# Altium schematic sheet Power Management.SchDoc("Power Management")
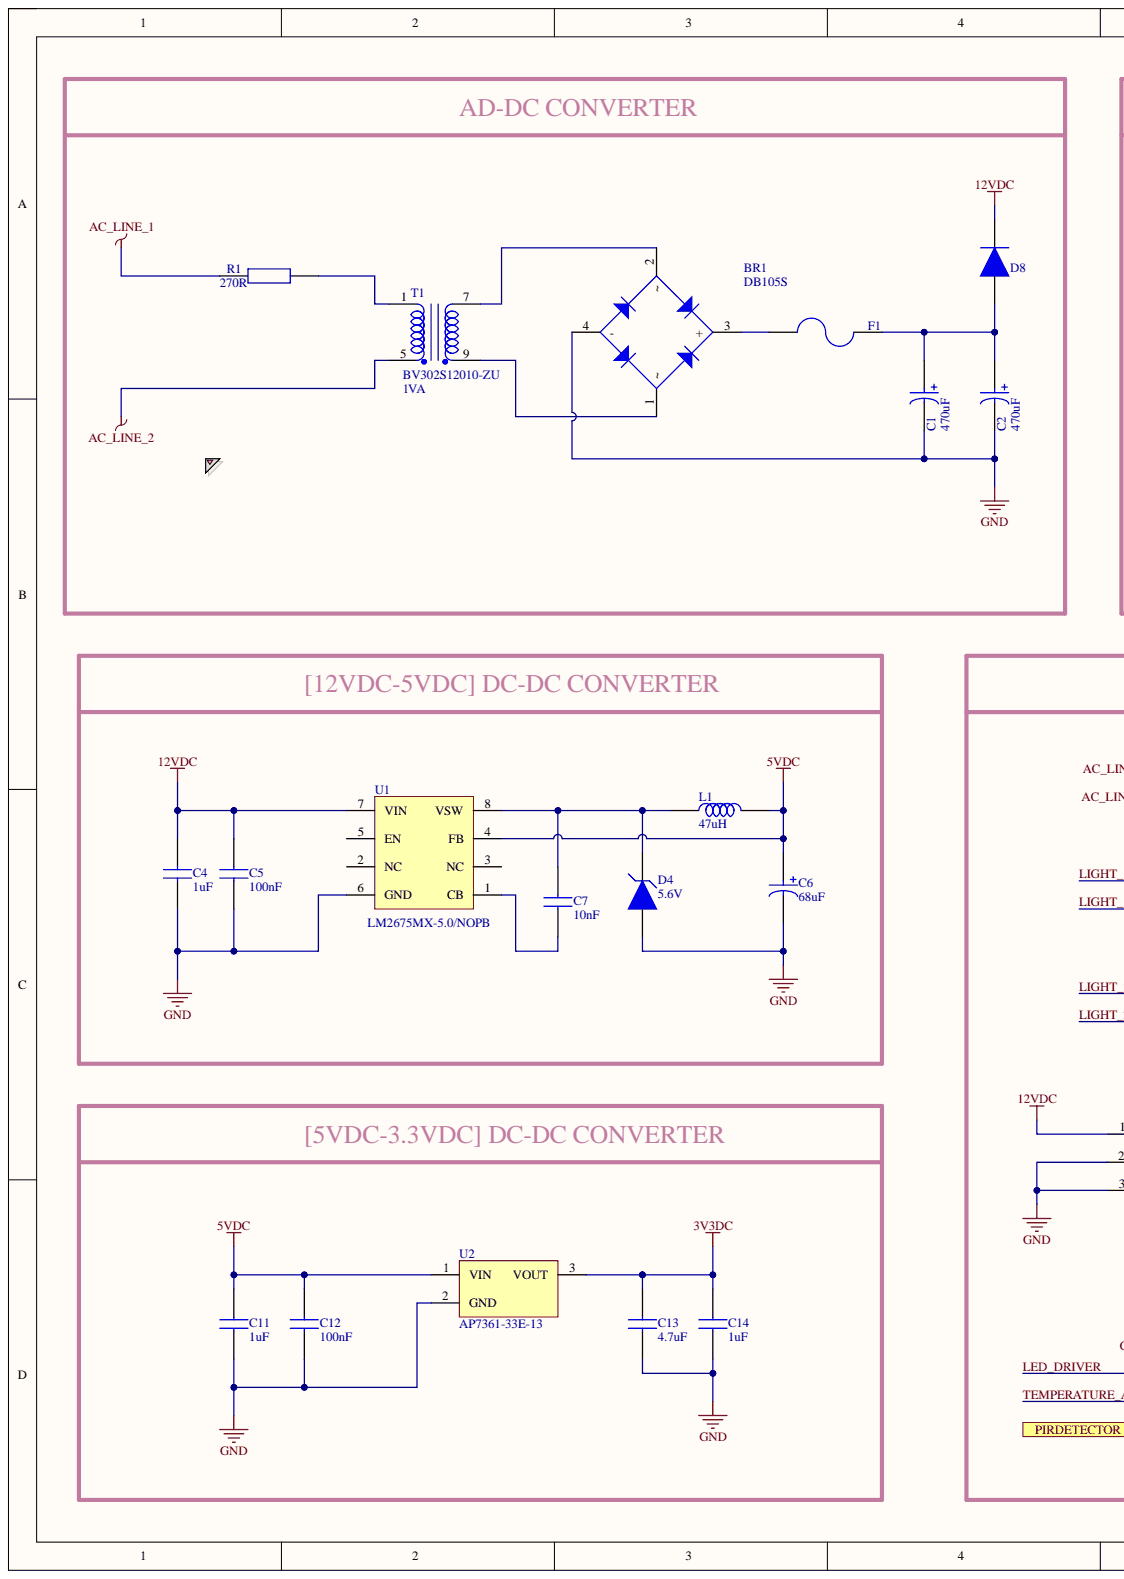
[diagram p0: Power Management.SchDoc, left]
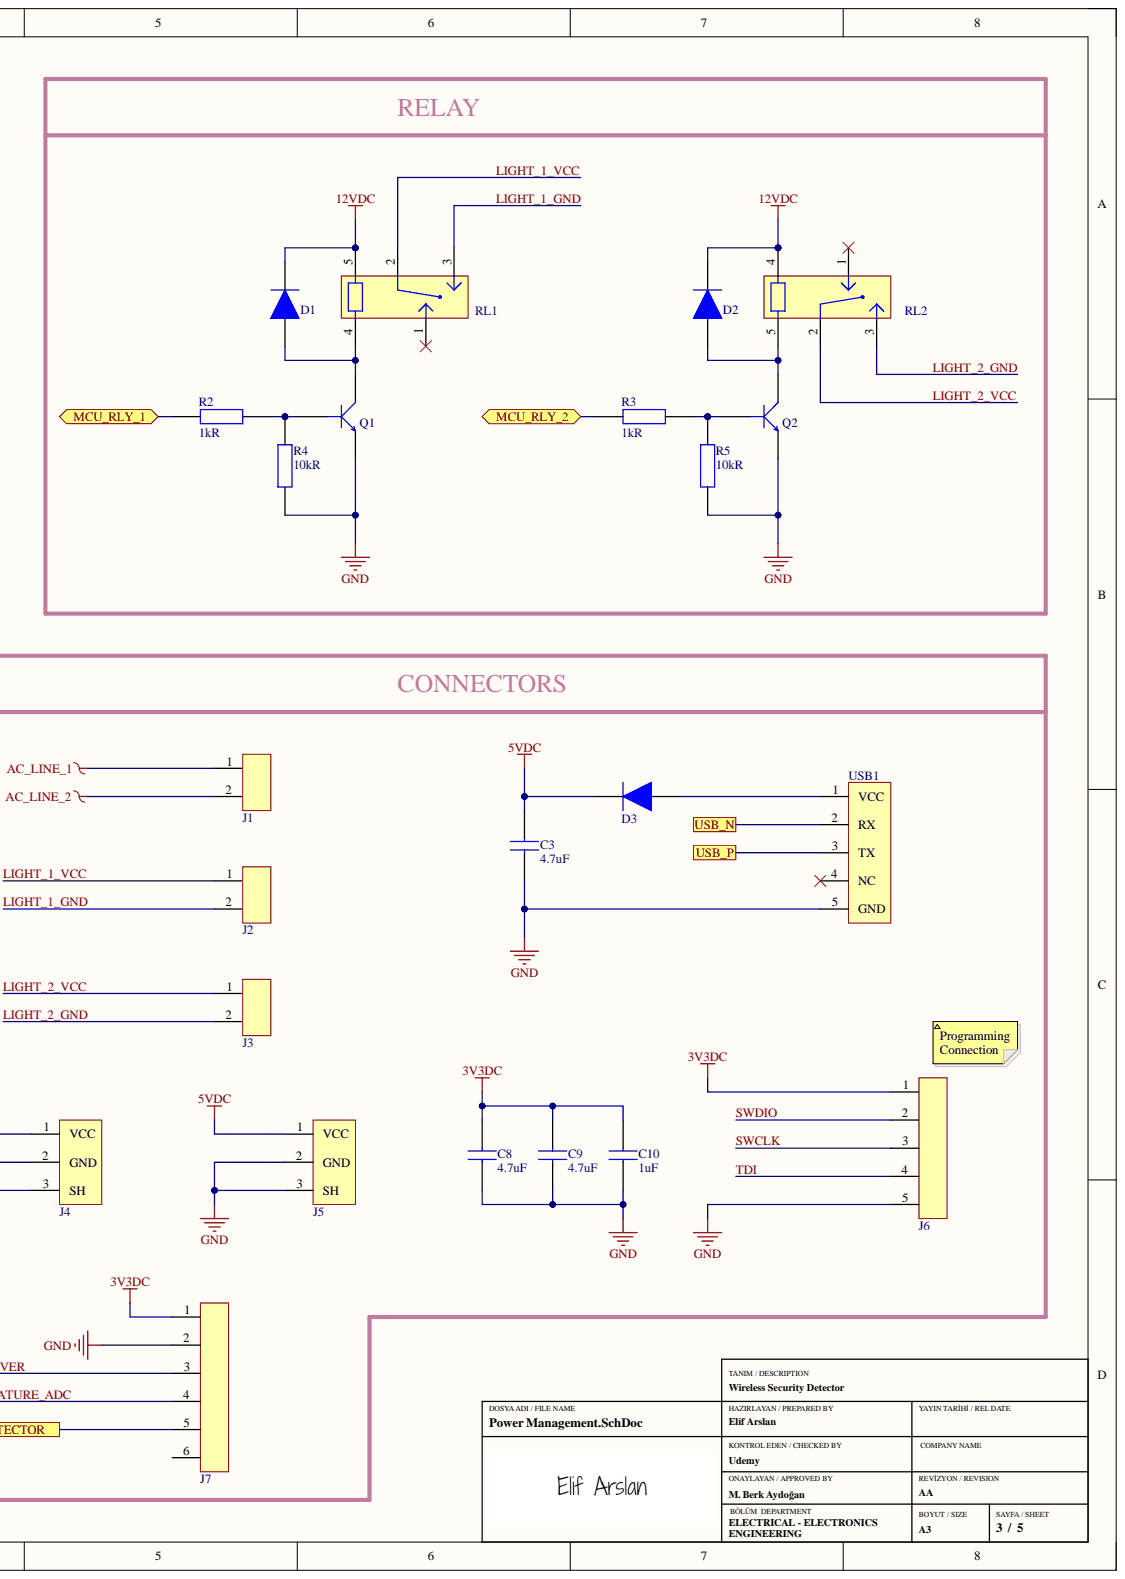
[diagram p1: Power Management.SchDoc, right]

## Parts
BR1  DB105S  [DB105S]
C1  Capacitance: 470uF  [CAP_RADIAL_D10_P5]  (hidden comment: ESH477M050AL3AA)
C2  Capacitance: 470uF  [CAP_RADIAL_D10_P5]  (hidden comment: ESH477M050AL3AA)
C3  Capacitance: 4.7uF  [CAP_0805]  (hidden comment: CL21A475KAQNNNE)
C4  Capacitance: 1uF  [CAP_0805]  (hidden comment: CL21B105KBFNNNE)
C5  Capacitance: 100nF  [CAP_0805]  (hidden comment: CL21B104KBCNNNC)
C6  Capacitance: 68uF  [CAP_EEVseries]  (hidden comment: EEV686M035A9HAA)
C7  Capacitance: 10nF  [CAP_0603]  (hidden comment: 0603B103K160CT)
C8  Capacitance: 4.7uF  [CAP_0805]  (hidden comment: CL21A475KAQNNNE)
C9  Capacitance: 4.7uF  [CAP_0805]  (hidden comment: CL21A475KAQNNNE)
C10  Capacitance: 1uF  [CAP_0805]  (hidden comment: CL21B105KBFNNNE)
C11  Capacitance: 1uF  [CAP_0805]  (hidden comment: CL21B105KBFNNNE)
C12  Capacitance: 100nF  [CAP_0603]  (hidden comment: C0603C104K4RACTU)
C13  Capacitance: 4.7uF  [CAP_0805]  (hidden comment: CL21A475KAQNNNE)
C14  Capacitance: 1uF  [CAP_0805]  (hidden comment: CL21B105KBFNNNE)
D1  1N4148WS  [SOD323F]  (value not drawn)
D2  1N4148WS  [SOD323F]  (value not drawn)
D3  1N4148WS  [SOD323F]  (value not drawn)
D4  Voltage Rating (DC): 5.6V  [SMB]  (hidden comment: 1SMB5919BT3G)
D8  1N4148WS  [SOD323F]  (value not drawn)
F1  FUSE 3413.0216.22  [3413.0216.22]  (value not drawn)
J1  TBP01R1-508-02BE  [TBP01R1-508]  (value not drawn)
J2  TBP01R1-508-02BE  [TBP01R1-508]  (value not drawn)
J3  TBP01R1-508-02BE  [TBP01R1-508]  (value not drawn)
J4  PJ-037AH-SMT-TR  [JACK]  (value not drawn)
J5  PJ-037AH-SMT-TR  [JACK]  (value not drawn)
J6  SMH-105-02-L-S  [PINHEADER_1x5_RA]  (value not drawn)
J7  64800611622  [64800611622]  (value not drawn)
L1  Inductance: 47uH  [IND_1212]  (hidden comment: 78438335470)
Q1  MMBT3904-7-F  [SOT-23F]  (value not drawn)
Q2  MMBT3904-7-F  [SOT-23F]  (value not drawn)
R1  Resistance: 270R  [RES_AXIAL_W]  (hidden comment: 5WD27)
R2  Resistance: 1kR  [RES_0603]  (hidden comment: RC0603FR-071KL)
R3  Resistance: 1kR  [RES_0603]  (hidden comment: RC0603FR-071KL)
R4  Resistance: 10kR  [RES_0603]  (hidden comment: RC0603FR-0710KL)
R5  Resistance: 10kR  [RES_0603]  (hidden comment: RC0603FR-0710KL)
RL1  ALQ112  [ALQ112]  (value not drawn)
RL2  ALQ112  [ALQ112]  (value not drawn)
T1  BV302S12010-ZU  Rated Power: 1VA  [BV302S]
U1  LM2675MX-5.0/NOPB  [SOIC8]
U2  AP7361-33E-13  [SOT-223]
USB1  10118192-0001LF  [MICRO_B_USB]  (value not drawn)

## Ports
MCU_RLY_1, MCU_RLY_2, PIRDETECTOR, USB_N, USB_P

## Nets
3V3DC: C8.2 C9.2 C10.2 C13.2 C14.2 J6.1 J7.1 U2.3
5VDC: C3.2 C6.1 C11.2 C12.2 D3.1 J5.1 L1.2 U1.4(FB) U2.1
12VDC: C4.2 C5.2 D1.1 D2.1 D8.1 J4.1 RL1.5 RL2.4 U1.7(VIN)
AC_LINE_1: J1.1 R1.1
AC_LINE_2: J1.2 T1.5
GND: BR1.4(-) C1.2 C2.2 C3.1 C4.1 C5.1 C6.2 C8.1 C9.1 C10.1 C11.1 C12.1 C13.1 C14.1 D4.A J4.2 J4.3 J5.2 J5.3 J6.5 J7.2 Q1.2 Q2.2 R4.1 R5.1 U1.6(GND) U2.2 USB1.5(GND)
LED_DRIVER: J7.3
LIGHT_1_GND: J2.2 RL1.3
LIGHT_1_VCC: J2.1 RL1.2
LIGHT_2_GND: J3.2 RL2.3
LIGHT_2_VCC: J3.1 RL2.2
MCU_RLY_1: R2.1 ; port MCU_RLY_1
MCU_RLY_2: R3.1 ; port MCU_RLY_2
PIRDETECTOR: J7.5 ; port PIRDETECTOR
SWCLK: J6.3
SWDIO: J6.2
TDI: J6.4
TEMPERATURE_ADC: J7.4
USB_N: USB1.2(RX) ; port USB_N
USB_P: USB1.3(TX) ; port USB_P
NetBR1_1: BR1.1(~) T1.9
NetBR1_2: BR1.2(~) T1.7
NetBR1_3: BR1.3(+) F1.1
NetC1_1: C1.1 C2.1 D8.2 F1.2
NetC7_1: C7.1 U1.1(CB)
NetC7_2: C7.2 D4.C L1.1 U1.8(VSW)
NetD1_2: D1.2 Q1.3 RL1.4
NetD2_2: D2.2 Q2.3 RL2.5
NetD3_2: D3.2 USB1.1(VCC)
NetQ1_1: Q1.1 R2.2 R4.2
NetQ2_1: Q2.1 R3.2 R5.2
NetR1_2: R1.2 T1.1

Not connected: J7 6; RL1 1; RL2 1; U1 2(NC) 3(NC) 5(EN); USB1 4(NC)

## Library data of the parts
BR1 = DB105S: Bridge Rect 1PHASE 600V 1A Db-s; Manufacturer: Sangdest Microelectronics; Category: Uncategorized; Component Kind: Standard; Library Name: UDEMY_Library.IntLib; Manufacturer Part Number: DB105S; Max Current: 1A; Operating Voltage: 600V; Pricing 1: 1=0,43000, 10=0,32600, 100=0,20330, 500=0,13910 (USD); RoHS: No; Stock 1: 30819; Supplier 1: Digi-Key; Supplier Part Number 1: 1655-DB105SCT-ND
C1 C2 = CAP ALU ELEC 470uF 50V: CAP ALUM 470UF 20% 50V RADIAL; Manufacturer: KEMET; Dielectric Material: Aluminium; Dissipation Factor: 12%; Lead Diameter: 0.6mm; Lead Length: 20mm; Leakage Current: 235uA; Life (Hours): 2000Hour; Polarity: Polar; Ripple Current: 730mA; Case/Package: Radial; Category: Aluminum Electrolytic Capacitors; Component Kind: Standard; ComponentLink1Description: https://datasheet.ciiva.com/18446/70233271-18446534.pdf?src-supplier=Allied+Electronics; ComponentLink1URL: https://datasheet.ciiva.com/18446/70233271-18446534.pdf?src-supplier=Allied+Electronics; ComponentLink2Description: https://datasheet.ciiva.com/22627/1794402-22627149.pdf?src-supplier=Farnell; ComponentLink2URL: https://datasheet.ciiva.com/22627/1794402-22627149.pdf?src-supplier=Farnell; Diameter: 13mm; Height: 20mm; Height - Seated (Max): 0.866inch; Lead Pitch: 5mm; Length: 20mm; Library Name: UDEMY_Library.IntLib; Manufacturer Part Number: ESH477M050AL3AA; Max Operating Temperature: 105°C; Min Operating Temperature: -40°C; Mount: Through Hole,PCB; Package Quantity: 2000; Packaging: Bulk; Pricing 1: 1=0,85000, 10=0,62700, 50=0,50140, 100=0,42970, 500=0,34020, 1000=0,28649, 2500=0,26858, 5000=0,25068 (USD); Radiation Hardening: No; REACH SVHC: No SVHC; RoHS: Yes; Stock 1: 4055; Supplier 1: Digi-Key; Supplier Part Number 1: 399-6594-ND; Termination: Radial; Tolerance: 20%; Voltage: 50V; Voltage Rating: 50V; Voltage Rating (DC): 50V
C3 C8 C9 C13 = CAP CER  4.7uF 0805: CAP CER 4.7UF 25V X5R %10 0805; Manufacturer: Samsung; Tolerance: 10%; Case Code (Metric): 2012; Case Code (Imperial): 0805; Case/Package: 0805; Category: Ceramic Capacitors; Component Kind: Standard; ComponentLink1Description: https://datasheet.ciiva.com/25798/cl21a475kaqnnne-25798882.pdf?src-supplier=Digi-Key; ComponentLink1URL: https://datasheet.ciiva.com/25798/cl21a475kaqnnne-25798882.pdf?src-supplier=Digi-Key; Construction: Flat; Depth: 1.25mm; Dielectric: X5R; Height: 1.25mm; Lead Free: Lead Free; Length: 2mm; Library Name: UDEMY_Library.IntLib; Manufacturer Part Number: CL21A475KAQNNNE; Max Operating Temperature: 85°C; Min Operating Temperature: -55°C; Mount: Surface Mount; Package Quantity: 1; Packaging: Tape and Reel; Pricing 1: 1=0,10000, 10=0,07300, 50=0,04140, 100=0,03440, 500=0,02408, 1000=0,01994 (USD); Radiation Hardening: No; RoHS: Yes; RoHS Compliant: Yes; Series: CL; Stock 1: 5034219; Supplier 1: Digi-Key; Supplier Part Number 1: 1276-1244-1-ND; Thickness: 0.055inch; Voltage Rating: 25V; Voltage Rating (DC): 25V; Width: 0.049inch
C4 C10 C11 C14 = CAP CER 1uF 0805: CAP CER 1UF %10 50V 0805; Manufacturer: Samsung; Dielectric: X7R; Tolerance: 10%; Case Code (Metric): 2012; Case Code (Imperial): 0805; Case/Package: 0805; Category: Ceramic Capacitors; Component Kind: Standard; ComponentLink1Description: https://datasheet.ciiva.com/25800/cl21b105kbfnnne-25800291.pdf?src-supplier=Digi-Key; ComponentLink1URL: https://datasheet.ciiva.com/25800/cl21b105kbfnnne-25800291.pdf?src-supplier=Digi-Key; ComponentLink2Description: https://datasheet.ciiva.com/16449/cl21b105kbfnnne-16449003.pdf?src-supplier=Future+Electronics; ComponentLink2URL: https://datasheet.ciiva.com/16449/cl21b105kbfnnne-16449003.pdf?src-supplier=Future+Electronics; ComponentLink3Description: https://datasheet.ciiva.com/26838/getdatasheetpartid-354075-26838668.pdf?src-supplier=Verical; ComponentLink3URL: https://datasheet.ciiva.com/26838/getdatasheetpartid-354075-26838668.pdf?src-supplier=Verical; Construction: Flat; Depth: 1.25mm; Height: 1.25mm; Length: 2mm; Library Name: UDEMY_Library.IntLib; Manufacturer Part Number: CL21B105KBFNNNE; Max Operating Temperature: 125°C; Min Operating Temperature: -55°C; Mount: Surface Mount; Package Quantity: 1; Packaging: Tape and Reel; Pricing 1: 1=0,11000, 10=0,07900, 50=0,04500, 100=0,03730, 500=0,02616, 1000=0,02165 (USD); Radiation Hardening: No; RoHS: Yes; RoHS Compliant: Yes; Series: CL; Stock 1: 177693; Supplier 1: Digi-Key; Supplier Part Number 1: 1276-1029-1-ND; Thickness: 0.053inch; Voltage: 50V; Voltage Rating: 50V; Width: 0.049inch
C5 = CAP CER 100nF 0805: CAP CER 0.1UF  %10 50V X7R  0805; Manufacturer: Samsung; Material: Ceramic; Tolerance: 10%; Case Code (Metric): 2012; Case Code (Imperial): 0805; Case/Package: 0805; Category: Ceramic Capacitors; Component Kind: Standard; ComponentLink1Description: https://datasheet.ciiva.com/25710/cl21b104kbcnnnc-25710182.pdf?src-supplier=Digi-Key; ComponentLink1URL: https://datasheet.ciiva.com/25710/cl21b104kbcnnnc-25710182.pdf?src-supplier=Digi-Key; ComponentLink2Description: https://datasheet.ciiva.com/29199/454152-da-01-en-vs-kondensator-0805-29199814.pdf?src-supplier=Conrad; ComponentLink2URL: https://datasheet.ciiva.com/29199/454152-da-01-en-vs-kondensator-0805-29199814.pdf?src-supplier=Conrad; Construction: Flat; Contact Plating: Tin; Depth: 1.25mm; Dielectric: X7R; Height: 0.85mm; Lead Free: Lead Free; Length: 2mm; Library Name: UDEMY_Library.IntLib; Manufacturer Part Number: CL21B104KBCNNNC; Max Operating Temperature: 125°C; Min Operating Temperature: -55°C; Mount: Surface Mount; Packaging: Tape and Reel; Pricing 1: 1=0,10000, 10=0,04400, 50=0,02400, 100=0,01960, 500=0,01404, 1000=0,01103 (USD); Radiation Hardening: No; RoHS: Yes; RoHS Compliant: Yes; Series: CL; Stock 1: 4716074; Supplier 1: Digi-Key; Supplier Part Number 1: 1276-1003-1-ND; Thickness: 0.037inch; Voltage: 50V; Voltage Rating: 50V; Voltage Rating (DC): 50V; Width: 0.049inch
C6 = CAP ALU 68uF: CAP ALUM 68UF 20% 35V SMD; Manufacturer: KEMET; Life (Hours): 2000Hour; Ripple Current: 196mA; Impedance: 340mR; Case/Package: Radial; Category: Aluminum Electrolytic Capacitors; Component Kind: Standard; ComponentLink1Description: https://datasheet.ciiva.com/5365/kem-a4002-eev-535332-5365784.pdf?src-supplier=Mouser; ComponentLink1URL: https://datasheet.ciiva.com/5365/kem-a4002-eev-535332-5365784.pdf?src-supplier=Mouser; Height - Seated (Max): 0.315inch; Length: 7.7mm; Library Name: UDEMY_Library.IntLib; Manufacturer Part Number: EEV686M035A9HAA; Max Operating Temperature: 105°C; Min Operating Temperature: -40°C; Mount: Surface Mount; Package Quantity: 1; Packaging: Tape and Reel; Pricing 1: 1=0,67000, 10=0,47200, 50=0,35680, 100=0,31080, 500=0,23024 (USD); RoHS: Yes; RoHS Compliant: Yes; Series: EEV; Stock 1: 9381; Supplier 1: Digi-Key; Supplier Part Number 1: 399-6722-1-ND; Termination: SMD/SMT; Tolerance: 20%; Voltage Rating: 35V; Voltage Rating (DC): 35V
C7 = CAP CER 10nF 0603: CAP CER 10nF %10 0603; Manufacturer: Walsin Technologies; Dielectric: X7R; Tolerance: 10%; Voltage Rating: 16V; Case Code (Metric): 1608; Case Code (Imperial): 0603; Case/Package: 0603; Category: Ceramic Capacitors; Component Kind: Standard; ComponentLink1Description: http://www.farnell.com/datasheets/1958513.pdf?src-supplier=Farnell; ComponentLink1URL: http://www.farnell.com/datasheets/1958513.pdf?src-supplier=Farnell; ComponentLink2Description: http://www.farnell.com/datasheets/1958513.pdf?src-supplier=Element14; ComponentLink2URL: http://www.farnell.com/datasheets/1958513.pdf?src-supplier=Element14; ComponentLink3Description: http://www.passivecomponent.com/wp-content/uploads/2018/10/MLCC.pdf?src-supplier=Digi-Key; ComponentLink3URL: http://www.passivecomponent.com/wp-content/uploads/2018/10/MLCC.pdf?src-supplier=Digi-Key; Depth: 0.8mm; Length: 1.6mm; Library Name: UDEMY_Library.IntLib; Manufacturer Part Number: 0603B103K160CT; Max Operating Temperature: 125°C; Min Operating Temperature: -55°C; Packaging: Tape and Reel; Pricing 1: 1=0,10000, 10=0,03300, 50=0,01820, 100=0,01480, 500=0,01060, 1000=0,00833 (USD); REACH SVHC: Unknown; RoHS: Yes; RoHS Compliant: Yes; Stock 1: 155945; Supplier 1: Digi-Key; Supplier Part Number 1: 1292-1384-1-ND; Voltage Rating (DC): 16V
C12 = CAP CER 100nF 0603: CAP CER 100nF %10 0603 SMD; Manufacturer: KEMET; Dielectric: X7R; Dielectric Material: Ceramic; Dissipation Factor: 3.5%; Tolerance: 10%; Voltage: 16V; Case Code (Metric): 1608; Case Code (Imperial): 0603; Case/Package: 0603; Category: Ceramic Capacitors; Component Kind: Standard; ComponentLink1Description: https://datasheet.ciiva.com/22749/kem-c1002-x7r-smd-22749657.pdf?src-supplier=Element14; ComponentLink1URL: https://datasheet.ciiva.com/22749/kem-c1002-x7r-smd-22749657.pdf?src-supplier=Element14; ComponentLink2Description: https://datasheet.ciiva.com/14589/70095383-14589014.pdf?src-supplier=Allied+Electronics; ComponentLink2URL: https://datasheet.ciiva.com/14589/70095383-14589014.pdf?src-supplier=Allied+Electronics; ComponentLink3Description: https://datasheet.ciiva.com/5413/kem-c1002-x7r-smd-5413791.pdf?src-supplier=Mouser; ComponentLink3URL: https://datasheet.ciiva.com/5413/kem-c1002-x7r-smd-5413791.pdf?src-supplier=Mouser; ComponentLink4Description: https://datasheet.ciiva.com/18192/getdatasheetpartid-330584-18192695.pdf?src-supplier=Verical; ComponentLink4URL: https://datasheet.ciiva.com/18192/getdatasheetpartid-330584-18192695.pdf?src-supplier=Verical; Construction: Flat; Contact Plating: Tin,Matte; Depth: 0.8mm; Height: 0.8mm; Lead Pitch: 0.7mm; Length: 1.6mm; Library Name: UDEMY_Library.IntLib; Manufacturer Part Number: C0603C104K4RACTU; Max Operating Temperature: 125°C; Min Operating Temperature: -55°C; Mount: PCB,Surface Mount; Number of Pins: 2; Package Quantity: 4000; Packaging: Tape and Reel; Pricing 1: 1=0,10000, 10=0,07200, 50=0,03940, 100=0,03220, 500=0,02298, 1000=0,01805 (USD); Radiation Hardening: No; REACH SVHC: No SVHC; RoHS: Yes; Series: C0603C; Stock 1: 3101112; Supplier 1: Digi-Key; Supplier Part Number 1: 399-C0603C104K4RAC7867CT-ND; Termination: SMD/SMT; Thickness: 0.034inch; Voltage Rating: 16V; Voltage Rating (DC): 16V; Weight: 7.1E-05oz; Width: 0.8mm
D1-D3 D8 = 1N4148WS: VR=75V IF=150mA Trr=4ns P=200mW; Manufacturer: ON Semiconductor / Fairchild; Average Rectified Current: 150mA; Element Configuration: Single; Forward Voltage: 1V; Max Forward Surge Current (Ifsm): 2A; Max Repetitive Reverse Voltage (Vrrm): 75V; Max Reverse Current: 5uA; Power Dissipation: 200mW; Recovery Time: 4ns; Category: Rectifier Diodes; Component Kind: Standard; ComponentLink1Description: https://datasheet.ciiva.com/30479/0900766b810e1cd3-30479206.pdf?src-supplier=RSComponents; ComponentLink1URL: https://datasheet.ciiva.com/30479/0900766b810e1cd3-30479206.pdf?src-supplier=RSComponents; ComponentLink2Description: https://datasheet.ciiva.com/15208/getdatasheetpartid-127819-15208358.pdf?src-supplier=Verical; ComponentLink2URL: https://datasheet.ciiva.com/15208/getdatasheetpartid-127819-15208358.pdf?src-supplier=Verical; ComponentLink3Description: https://datasheet.ciiva.com/8300/1n914bws-8300360.pdf?src-supplier=Avnet; ComponentLink3URL: https://datasheet.ciiva.com/8300/1n914bws-8300360.pdf?src-supplier=Avnet; ComponentLink4Description: https://datasheet.ciiva.com/910/1n4148ws-910381.pdf?src-supplier=Digi-Key; ComponentLink4URL: https://datasheet.ciiva.com/910/1n4148ws-910381.pdf?src-supplier=Digi-Key; ComponentLink5Description: https://datasheet.ciiva.com/910/1n4148ws-910382.pdf?src-supplier=Digi-Key; ComponentLink5URL: https://datasheet.ciiva.com/910/1n4148ws-910382.pdf?src-supplier=Digi-Key; ComponentLink6Description: https://datasheet.ciiva.com/910/1n4148ws-910380.pdf?src-supplier=Digi-Key; ComponentLink6URL: https://datasheet.ciiva.com/910/1n4148ws-910380.pdf?src-supplier=Digi-Key; Contact Plating: Tin,Matte; Forward Current: 150mA; Height: 1mm; Lead Free: Lead Free; Length: 1.8mm; Library Name: UDEMY_Library.IntLib; Manufacturer Part Number: 1N4148WS; Max Operating Temperature: 150°C; Max Reverse Voltage (DC): 75V; Min Operating Temperature: -55°C; Mount: Surface Mount; Number of Pins: 2; Package Quantity: 1; Packaging: Tape and Reel; Pricing 1: 1=0,21000, 10=0,19200, 100=0,10460, 500=0,06436, 1000=0,04388 (USD); Radiation Hardening: No; REACH SVHC: No SVHC; Reverse Recovery Time: 4ns; Reverse Voltage: 75V; RoHS: Yes; RoHS Compliant: Yes; Series: -; Stock 1: 1631860; Supplier 1: Digi-Key; Supplier Part Number 1: 1N4148WSFSCT-ND; Weight: 0.0276g; Width: 1.35mm
D4 = 1SMB5919BT3G: Zener Diode 5.6 V 3 W ±5% Surface Mount SMB; Manufacturer: ON Semiconductor; Breakdown Voltage: 5.88V; Element Configuration: Single; ESD Protection: Yes; Forward Voltage: 1.5V; Material: Plastic; Max Power Dissipation: 3W; Max Reverse Current: 5uA; Power Dissipation: 550mW; Test Current: 66.9mA; Zener Current: 267mA; Impedance: 2R; Case/Package: SMB; Category: Zener Diodes; Component Kind: Standard; ComponentLink1Description: https://datasheet.ciiva.com/27747/1308331-27747654.pdf?src-supplier=Jameco; ComponentLink1URL: https://datasheet.ciiva.com/27747/1308331-27747654.pdf?src-supplier=Jameco; ComponentLink2Description: https://datasheet.ciiva.com/1182/1smb5913bt3-d-1182657.pdf?src-supplier=Element14; ComponentLink2URL: https://datasheet.ciiva.com/1182/1smb5913bt3-d-1182657.pdf?src-supplier=Element14; ComponentLink3Description: https://datasheet.ciiva.com/1182/1smb5913bt3-d-1182658.pdf?src-supplier=Element14; ComponentLink3URL: https://datasheet.ciiva.com/1182/1smb5913bt3-d-1182658.pdf?src-supplier=Element14; ComponentLink4Description: https://datasheet.ciiva.com/16311/1smb5913bt3-d-16311847.pdf?src-supplier=Future+Electronics; ComponentLink4URL: https://datasheet.ciiva.com/16311/1smb5913bt3-d-16311847.pdf?src-supplier=Future+Electronics; ComponentLink5Description: https://datasheet.ciiva.com/15198/getdatasheetpartid-311040-15198695.pdf?src-supplier=Verical; ComponentLink5URL: https://datasheet.ciiva.com/15198/getdatasheetpartid-311040-15198695.pdf?src-supplier=Verical; ComponentLink6Description: https://datasheet.ciiva.com/895/1smb5913bt3-d-895204.pdf?src-supplier=Digi-Key; ComponentLink6URL: https://datasheet.ciiva.com/895/1smb5913bt3-d-895204.pdf?src-supplier=Digi-Key; ComponentLink7Description: https://datasheet.ciiva.com/895/1smb5913bt3-d-895205.pdf?src-supplier=Digi-Key; ComponentLink7URL: https://datasheet.ciiva.com/895/1smb5913bt3-d-895205.pdf?src-supplier=Digi-Key; ComponentLink8Description: https://datasheet.ciiva.com/895/1smb5913bt3-d-895203.pdf?src-supplier=Digi-Key; ComponentLink8URL: https://datasheet.ciiva.com/895/1smb5913bt3-d-895203.pdf?src-supplier=Digi-Key; Contact Plating: Tin,Matte; Halogen Free: Halogen Free; Height: 2.13mm; Lead Free: Lead Free; Length: 4.32mm; Library Name: UDEMY_Library.SchLib; Manufacturer Part Number: 1SMB5919BT3G; Max Operating Temperature: 150°C; Max Reverse Leakage Current: 5uA; Min Operating Temperature: -65°C; Number of Pins: 2; Package Quantity: 1; Packaging: Tape and Reel; Power Rating: 3W; Radiation Hardening: No; REACH SVHC: No SVHC; RoHS: Yes; RoHS Compliant: Yes; Supplier 1: Digi-Key; Supplier Part Number 1: 1SMB5919BT3GOSCT-ND; Tolerance: 5%; Voltage Tolerance: 5%; Width: 3.56mm; Working Voltage: 5.6V; Zener Voltage: 5.6V
F1 = FUSE 3413.0216.22: 1 A 32 V AC 63 V DC Fuse Board Mount (Cartridge Style Excluded) Surface Mount 1206 (3216 Metric); Manufacturer: Schurter; Approvals: VDE,cURus; Body Material: Glass,Epoxy; Current Rating: 1A; DC Cold Resistance: 94.2mR; Max Voltage Rating (AC): 32V; Max Voltage Rating (DC): 63V; Case Code (Metric): 3216; Case Code (Imperial): 1206; Case/Package: 1206; Category: Fuses; Component Kind: Standard; ComponentLink1Description: https://datasheet.ciiva.com/301/typ-usi-1206-301440.pdf?src-supplier=Digi-Key; ComponentLink1URL: https://datasheet.ciiva.com/301/typ-usi-1206-301440.pdf?src-supplier=Digi-Key; ComponentLink2Description: https://datasheet.ciiva.com/14541/70160006-14541114.pdf?src-supplier=Allied+Electronics; ComponentLink2URL: https://datasheet.ciiva.com/14541/70160006-14541114.pdf?src-supplier=Allied+Electronics; ComponentLink3Description: https://datasheet.ciiva.com/301/typ-usi-1206-301439.pdf?src-supplier=Digi-Key; ComponentLink3URL: https://datasheet.ciiva.com/301/typ-usi-1206-301439.pdf?src-supplier=Digi-Key; ComponentLink4Description: https://datasheet.ciiva.com/301/typ-usi-1206-301441.pdf?src-supplier=Digi-Key; ComponentLink4URL: https://datasheet.ciiva.com/301/typ-usi-1206-301441.pdf?src-supplier=Digi-Key; ComponentLink5Description: https://datasheet.ciiva.com/8264/typ-usi-1206-8264583.pdf?src-supplier=Avnet; ComponentLink5URL: https://datasheet.ciiva.com/8264/typ-usi-1206-8264583.pdf?src-supplier=Avnet; ComponentLink6Description: https://datasheet.ciiva.com/14102/qousi1206-data-e-14102903.pdf?src-supplier=Distrelec; ComponentLink6URL: https://datasheet.ciiva.com/14102/qousi1206-data-e-14102903.pdf?src-supplier=Distrelec; ComponentLink7Description: https://datasheet.ciiva.com/16316/typ-usi-1206-16316588.pdf?src-supplier=Future+Electronics; ComponentLink7URL: https://datasheet.ciiva.com/16316/typ-usi-1206-16316588.pdf?src-supplier=Future+Electronics; Contact Plating: Gold; Depth: 1.6mm; Fuse Type: Board Mount (Cartridge Style Excluded); Height: 0.6mm; Housing Material: Glass; Lead Free: Lead Free; Length: 3.2mm; Library Name: UDEMY_Library.IntLib; Manufacturer Part Number: 3413.0216.22; Max Operating Temperature: 90°C; Min Operating Temperature: -55°C; Mount: Surface Mount,PCB; Number of Pins: 2; Package Quantity: 1000; Packaging: Tape and Reel; Pricing 1: 1=0,67000, 50=0,52400, 100=0,39700, 500=0,32624 (USD); Radiation Hardening: No; RoHS: Yes; RoHS Compliant: Yes; Series: USI 1206; Stock 1: 6019; Supplier 1: Digi-Key; Supplier Part Number 1: 486-1144-1-ND; Terminal Material: Tin; Termination: SMD/SMT; Voltage Rating: 32V; Voltage Rating (AC): 32V; Voltage Rating (DC): 63V; Weight: 0.000212oz; Width: 1.6mm; Working Voltage: 32V
J1-J3 = TBP01R1-508-02BE: 2~24 Poles, Pluggable, Receptacle, Horizontal, 5.08 Pitch, Terminal Block Connector; Manufacturer: CUI Devices; Category: Terminal Blocks; Component Kind: Standard; Library Name: UDEMY_Library.SchLib; Manufacturer Part Number: TBP01R1-508-02BE; Pricing 1: 1=0,59000, 10=0,30800, 25=0,21800, 50=0,18560, 100=0,17900, 400=0,15135, 800=0,13020, 1200=0,12369, 5200=0,09439 (USD); RoHS: Yes; Stock 1: 149707; Supplier 1: Digi-Key; Supplier Part Number 1: 102-6406-ND
J4 J5 = PJ-037AH-SMT-TR: 2.0 x 6.3 mm, 5.0 A, Horizontal, Surface Mount (SMT), Dc Power Jack Connector; Manufacturer: CUI Devices; Category: Power Connectors; Component Kind: Standard; Library Name: UDEMY_Library.IntLib; Manufacturer Part Number: PJ-037AH-SMT-TR; Pricing 1: 1=1,51000, 10=1,31700, 25=1,26120, 50=1,23320, 100=1,17720 (USD); RoHS: Yes; Stock 1: 7569; Supplier 1: Digi-Key; Supplier Part Number 1: CP-037AHPJCT-ND
J6 = SMH-105-02-L-S: 5 Position Receptacle Connector 0.100" (2.54mm) Surface Mount, Right Angle Gold; Manufacturer: Samtec; Contact Resistance: 10mR; Number of Contacts: 4; Category: Headers and Wire Housings; Component Kind: Standard; ComponentLink1Description: http://www.farnell.com/datasheets/1927708.pdf?_ga=2.237598468.569325269.1503285096-1413671594.1503025027&src-supplier=Farnell; ComponentLink1URL: http://www.farnell.com/datasheets/1927708.pdf?_ga=2.237598468.569325269.1503285096-1413671594.1503025027&src-supplier=Farnell; ComponentLink2Description: http://www.samtec.com/documents/webfiles/pdf/SMH.PDF?src-supplier=Newark; ComponentLink2URL: http://www.samtec.com/documents/webfiles/pdf/SMH.PDF?src-supplier=Newark; ComponentLink3Description: http://www.samtec.com/documents/webfiles/pdf/SMH.PDF?src-supplier=Avnet; ComponentLink3URL: http://www.samtec.com/documents/webfiles/pdf/SMH.PDF?src-supplier=Avnet; ComponentLink4Description: http://suddendocs.samtec.com/catalog_english/smh.pdf?src-supplier=Digi-Key; ComponentLink4URL: http://suddendocs.samtec.com/catalog_english/smh.pdf?src-supplier=Digi-Key; Contact Material: Bronze; Contact Plating: Gold; Depth: 10.8mm; Gender: Receptacle; Housing Color: Black; Housing Material: Polymer; Length: 13.21mm; Library Name: UDEMY_Library.IntLib; Manufacturer Part Number: SMH-105-02-L-S; Max Operating Temperature: 125°C; Min Operating Temperature: -55°C; Number of Rows: 1; Orientation: Horizontal; Packaging: Rail/Tube; Pitch: 2.54mm; Pricing 1: 1=2,27000, 43=1,84791, 86=1,76395, 473=1,47000, 946=1,26000, 4902=1,12000 (USD); Radiation Hardening: No; REACH SVHC: No SVHC; RoHS: Yes; Stock 1: 206; Supplier 1: Digi-Key; Supplier Part Number 1: SAM11813-ND; Termination: Solder
J7 = 64800611622_conn_6pin: 1.5mm 6PIN Connector; Manufacturer: Wurth Electronics; Component Kind: Standard; Library Name: UDEMY_Library.IntLib; Manufacturer Part Number: 64800611622; Pricing 1: 10=0,42700, 50=0,39500, 200=0,36700, 500=0,34300 (GBP); RoHS: No; Stock 1: 50; Supplier 1: RSComponents; Supplier Part Number 1: 2138727
L1 = IND 47uH: 47 µH Shielded Molded Inductor 390 mA 2.3Ohm Max 1212 (3030 Metric); Manufacturer: Wurth Electronics; Core Material: Ferrite; Current Rating: 390mA; DC Resistance (DCR): 2.3R; Saturation Current: 1.18A; Self Resonant Frequency: 8MHz; Test Frequency: 100kHz; Tolerance: 30%; Case Code (Metric): 3030; Case Code (Imperial): 1212; Case/Package: 1212; Category: Fixed Inductors; Component Kind: Standard; ComponentLink1Description: https://media.digikey.com/pdf/Data%20Sheets/Wurth%20Electronics%20PDFs/Q+_Products_Flyer.pdf?src-supplier=Digi-Key; ComponentLink1URL: https://media.digikey.com/pdf/Data%20Sheets/Wurth%20Electronics%20PDFs/Q+_Products_Flyer.pdf?src-supplier=Digi-Key; Height - Seated (Max): 0.059inch; Length: 0.118inch; Library Name: UDEMY_Library.IntLib; Manufacturer Part Number: 78438335470; Max Operating Temperature: 125°C; Min Operating Temperature: -40°C; Mount: Surface Mount; Packaging: Tape and Reel; Pricing 1: 1=2,11000, 50=1,97000, 250=1,80300, 500=1,67200 (USD); Ratings: AEC-Q200; Resistor Type: Wirewound; RoHS: Yes; RoHS Compliant: Yes; Shielding: Shielded; Stock 1: 888; Supplier 1: Digi-Key; Supplier Part Number 1: 732-6947-1-ND; Width: 0.118inch
Q1 Q2 = MMBT3904-7-F: Bipolar (BJT) Transistor NPN 40 V 200 mA 300MHz 300 mW Surface Mount SOT-23-3; Manufacturer: Diodes Zetex; Collector Base Voltage (VCBO): 60V; Collector Emitter Breakdown Voltage: 40V; Collector Emitter Saturation Voltage: 300mV; Continuous Collector Current: 200mA; Element Configuration: Single; Emitter Base Voltage (VEBO): 6V; hFE Min: 100; Max Power Dissipation: 300mW; Number of Elements: 1; Power Dissipation: 350mW; Case/Package: SOT-23; Category: BJTs; Collector Emitter Voltage (VCEO): 40V; Collector-emitter Voltage-Max: 300mV; Component Kind: Standard; ComponentLink1Description: https://datasheet.ciiva.com/11201/ds30036-11201778.pdf?src-supplier=Avnet; ComponentLink1URL: https://datasheet.ciiva.com/11201/ds30036-11201778.pdf?src-supplier=Avnet; ComponentLink2Description: https://datasheet.ciiva.com/15138/getdatasheetpartid-53265-15138780.pdf?src-supplier=Verical; ComponentLink2URL: https://datasheet.ciiva.com/15138/getdatasheetpartid-53265-15138780.pdf?src-supplier=Verical; ComponentLink3Description: https://datasheet.ciiva.com/22711/1915516-22711750.pdf?src-supplier=Element14; ComponentLink3URL: https://datasheet.ciiva.com/22711/1915516-22711750.pdf?src-supplier=Element14; ComponentLink4Description: https://datasheet.ciiva.com/11198/ds30036-11198999.pdf?src-supplier=Avnet; ComponentLink4URL: https://datasheet.ciiva.com/11198/ds30036-11198999.pdf?src-supplier=Avnet; ComponentLink5Description: https://datasheet.ciiva.com/6361/ds30036-6361256.pdf?src-supplier=Digi-Key; ComponentLink5URL: https://datasheet.ciiva.com/6361/ds30036-6361256.pdf?src-supplier=Digi-Key; ComponentLink6Description: https://datasheet.ciiva.com/6361/ds30036-6361254.pdf?src-supplier=Digi-Key; ComponentLink6URL: https://datasheet.ciiva.com/6361/ds30036-6361254.pdf?src-supplier=Digi-Key; Contact Plating: Tin,Matte; Frequency: 300MHz; Gain Bandwidth Product: 300MHz; Height: 1mm; Lead Free: Lead Free; Length: 3.05mm; Library Name: UDEMY_Library.IntLib; Manufacturer Part Number: MMBT3904-7-F; Max Breakdown Voltage: 40V; Max Collector Current: 200mA; Max Frequency: 300MHz; Max Operating Temperature: 150°C; Min Operating Temperature: -55°C; Mount: Surface Mount; Number of Pins: 3; Package Quantity: 3000; Packaging: Tape and Reel; Polarity: NPN; Pricing 1: 1=0,13000, 10=0,11700, 100=0,06370, 500=0,03918, 1000=0,02671 (USD); Radiation Hardening: No; REACH SVHC: No SVHC; RoHS: Yes; RoHS Compliant: Yes; Series: -; Stock 1: 2495905; Supplier 1: Digi-Key; Supplier Part Number 1: MMBT3904-FDICT-ND; Transition Frequency: 300MHz; Voltage Rating (DC): 40V; Weight: 0.000282oz; Width: 1.4mm
R1 = 5WD27: 270 mOhms ±5% 5W Through Hole Resistor Axial - Wirewound; Manufacturer: NTE Electronics; Temperature Coefficient: 300ppm/°C; Case/Package: Axial; Category: Through-Hole Resistors; Component Kind: Standard; ComponentLink1Description: https://datasheet.ciiva.com/15772/fivewire-15772442.pdf?src-supplier=Onlinecomponents.com; ComponentLink1URL: https://datasheet.ciiva.com/15772/fivewire-15772442.pdf?src-supplier=Onlinecomponents.com; ComponentLink2Description: https://datasheet.octopart.com/5WD27-NTE-Electronics-datasheet-137435208.pdf?src-supplier=NTE+Electronics; ComponentLink2URL: https://datasheet.octopart.com/5WD27-NTE-Electronics-datasheet-137435208.pdf?src-supplier=NTE+Electronics; ComponentLink3Description: https://datasheet.octopart.com/5WD27-NTE-Electronics-datasheet-21210163.pdf?src-supplier=Master+Electronics; ComponentLink3URL: https://datasheet.octopart.com/5WD27-NTE-Electronics-datasheet-21210163.pdf?src-supplier=Master+Electronics; Library Name: UDEMY_Library.IntLib; Manufacturer Part Number: 5WD27; Max Operating Temperature: 155°C; Min Operating Temperature: -55°C; Mount: Through Hole; Power Rating: 5W; RoHS Compliant: No; Supplier 1: Newark; Supplier Part Number 1: 33C8814; Termination: Axial; Tolerance: 5%
R2 R3 = RES 1K OHM 0603: RES SMD 1K OHM %1 0603; Manufacturer: Yageo; Max Power Dissipation: 100mW; Resistance Tolerance: 1%; Temperature Coefficient: 100ppm/°C; Voltage Rating: 50V; Case Code (Metric): 1608; Case Code (Imperial): 0603; Case/Package: 0603; Category: Chip SMD Resistors; Component Kind: Standard; Construction: Rectangular; Contact Plating: Tin,Matte; Depth: 0.8mm; Features: Moisture Resistant; Height: 0.45mm; Lead Free: Lead Free; Length: 1.6mm; Library Name: UDEMY_Library.SchLib; Manufacturer Part Number: RC0603FR-071KL; Max Operating Temperature: 155°C; Military Standard: Not; Min Operating Temperature: -55°C; Number of Terminations: 2; Packaging: Tape and Reel; Power Rating: 100mW; Pricing 1: 5000=0,00288, 10000=0,00250, 25000=0,00220, 50000=0,00202, 125000=0,00198 (USD); Radiation Hardening: No; REACH SVHC: No SVHC; Resistor Type: Thick Film; RoHS: Yes; RoHS Compliant: Yes; Series: RC; Size Code: 0603; Stock 1: 10046977; Supplier 1: Digi-Key; Supplier Part Number 1: 311-1.00KHRTR-ND; Technology: THICK FILM; Termination: SMD/SMT; Tolerance: 1%
R4 R5 = RES 10K OHM 0603: RES 10K %1 OHM 0603 SMD; Manufacturer: Yageo; Max Power Dissipation: 100mW; Resistance Tolerance: 1%; Temperature Coefficient: 100ppm/°C; Voltage Rating: 75V; Voltage Rating (DC): 50V; Case Code (Metric): 1608; Case Code (Imperial): 0603; Case/Package: 0603; Category: Chip SMD Resistors; Component Kind: Standard; ComponentLink1Description: https://datasheet.ciiva.com/7660/38590-7660789.pdf?src-supplier=Element14; ComponentLink1URL: https://datasheet.ciiva.com/7660/38590-7660789.pdf?src-supplier=Element14; ComponentLink2Description: https://datasheet.ciiva.com/7983/443971-7983596.pdf?src-supplier=Element14; ComponentLink2URL: https://datasheet.ciiva.com/7983/443971-7983596.pdf?src-supplier=Element14; ComponentLink3Description: https://datasheet.ciiva.com/22747/443971-22747519.pdf?src-supplier=Element14; ComponentLink3URL: https://datasheet.ciiva.com/22747/443971-22747519.pdf?src-supplier=Element14; ComponentLink4Description: https://datasheet.ciiva.com/46440/1868787-46440891.pdf?src-supplier=Element14; ComponentLink4URL: https://datasheet.ciiva.com/46440/1868787-46440891.pdf?src-supplier=Element14; ComponentLink5Description: https://datasheet.ciiva.com/7746/1738695-7746239.pdf?src-supplier=Element14; ComponentLink5URL: https://datasheet.ciiva.com/7746/1738695-7746239.pdf?src-supplier=Element14; ComponentLink6Description: https://datasheet.ciiva.com/15189/getdatasheetpartid-409289-15189591.pdf?src-supplier=Verical; ComponentLink6URL: https://datasheet.ciiva.com/15189/getdatasheetpartid-409289-15189591.pdf?src-supplier=Verical; Construction: Rectangular; Depth: 0.8mm; Features: Moisture Resistant; Height: 0.45mm; Lead Free: Lead Free; Lead Pitch: 0.3mm; Length: 1.6mm; Library Name: UDEMY_Library.SchLib; Manufacturer Part Number: RC0603FR-0710KL; Max Operating Temperature: 155°C; Military Standard: Not; Min Operating Temperature: -55°C; Number of Pins: 2; Number of Terminations: 2; Packaging: Tape and Reel; Power Rating: 100mW; Pricing 1: 1=0,10000, 10=0,02200, 100=0,00890, 1000=0,00402, 2500=0,00349 (USD); REACH SVHC: Unknown; Resistor Type: Thick Film; RoHS: Yes; RoHS Compliant: Yes; Series: RC; Size Code: 0603; Stock 1: 43901522; Supplier 1: Digi-Key; Supplier Part Number 1: 311-10.0KHRCT-ND; Termination: SMD/SMT; Tolerance: 1%; Weight: 7.1E-05oz; Width: 0.8mm
RL1 RL2 = ALQ112: RELAY GEN PURPOSE SPDT 10A 12V; Manufacturer: Panasonic; Coil Current: 33.3mA; Coil Power: 400mW; Coil Resistance: 360R; Coil Type: Non-Latching; Max Voltage Rating (AC): 250V; Operate Time: 20ms; Relay Type: General Purpose; Case/Package: ALQ112; Category: Power Relays; Coil Voltage (DC): 12V; Component Kind: Standard; ComponentLink1Description: https://datasheet.ciiva.com/24388/307ds-61b20-en-lq-24388909.pdf?src-supplier=Onlinecomponents.com; ComponentLink1URL: https://datasheet.ciiva.com/24388/307ds-61b20-en-lq-24388909.pdf?src-supplier=Onlinecomponents.com; Contact Current Rating: 10A; Contact Material: Silver; Depth: 10mm; Height: 16mm; Lead Free: Lead Free; Length: 20mm; Library Name: UDEMY_Library.SchLib; Manufacturer Part Number: ALQ112; Max Operating Temperature: 85°C; Min Operating Temperature: -40°C; Number of Pins: 5; Package Quantity: 100; Packaging: Carton; Power Consumption: 400mW; Power Rating: 400mW; REACH SVHC: Unknown; Release Time: 20ms; RoHS: Yes; RoHS Compliant: Yes; Series: ALQ; Supplier 1: Digi-Key; Supplier Part Number 1: 255-3562-ND; Termination: PC Pin; Throw Configuration: SPDT; Voltage Rating (AC): 250V; Weight: 7g; Width: 10mm
T1 = BV302S12010-ZU: 230-12 Transformer 1VA; Manufacturer: Zettler Magnetics; Operating Frequency: 50-60Hz; Primary Voltage: 230V; Secondary Voltage: 12V; Component Kind: Standard; Library Name: UDEMY_Library.SchLib; Manufacturer Part Number: BV302S12010-ZU; RoHS: No; Supplier 1: Digi-Key; Supplier Part Number 1: 2469-BV302S12010-ZU-ND
U1 = LM2675MX-5.0/NOPB: IC REG BUCK 5V 1A 8SOIC; Manufacturer: TI National Semiconductor; Efficiency: 90%; Max Input Voltage: 40V; Min Input Voltage: 8V; Number of Outputs: 1; Output Configuration: Positive; Output Type: Fixed; Quiescent Current: 2.5mA; Switching Frequency: 260kHz; Synchronous Rectifier: No; Case/Package: SOIC; Category: Power Supply Modules; Component Kind: Standard; ComponentLink1Description: https://datasheet.ciiva.com/41457/001013883-da-01-en-ic-reg-buck-lm2675mx-5-0-nopb-soic-8-tid-41457895.pdf?src-supplier=Conrad; ComponentLink1URL: https://datasheet.ciiva.com/41457/001013883-da-01-en-ic-reg-buck-lm2675mx-5-0-nopb-soic-8-tid-41457895.pdf?src-supplier=Conrad; ComponentLink2Description: https://datasheet.ciiva.com/26811/getdatasheetpartid-85401-26811532.pdf?src-supplier=Verical; ComponentLink2URL: https://datasheet.ciiva.com/26811/getdatasheetpartid-85401-26811532.pdf?src-supplier=Verical; Contact Plating: Tin,Matte; Lead Free: Lead Free; Library Name: UDEMY_Library.IntLib; Manufacturer Part Number: LM2675MX-5.0/NOPB; Max Operating Temperature: 125°C; Max Output Current: 1A; Min Operating Temperature: -40°C; Min Output Voltage: 5V; Mount: Surface Mount; Nominal Output Voltage: 5V; Number of Pins: 8; Operating Supply Voltage: 40V; Output Current: 1A; Output Voltage: 5V; Package Quantity: 2500; Packaging: Tape and Reel; Radiation Hardening: No; REACH SVHC: No SVHC; RoHS: Yes; RoHS Compliant: Yes; Series: SIMPLE SWITCHER®; Supplier 1: Digi-Key; Supplier Part Number 1: LM2675MX-5.0-ND
U2 = AP7361-33E-13: AP7361 Series 3.3 V 1 A Low SMT Dropout Fixed-Mode Regulator - SOT-223; Manufacturer: Diodes; Accuracy: 1%; Dropout Voltage: 500mV; Max Output Current: 1A; Max Power Dissipation: 1.1W; Max Input Voltage: 6V; Min Current Limit: 1.1A; Min Input Voltage: 2.2V; Number of Outputs: 1; Output Type: Fixed; Output Voltage: 3.3V; Polarity: Positive; Power Dissipation: 1.1kW; Power Supply Rejection Ratio (PSRR): 65dB; Quiescent Current: 70uA; Reference Voltage: 800mV; Case/Package: SOT-223; Category: Voltage Regulators - Linear; Component Kind: Standard; ComponentLink1Description: https://datasheet.ciiva.com/26926/getdatasheetpartid-670786-26926141.pdf?src-supplier=Verical; ComponentLink1URL: https://datasheet.ciiva.com/26926/getdatasheetpartid-670786-26926141.pdf?src-supplier=Verical; ComponentLink2Description: https://datasheet.ciiva.com/25651/ap7361-25651049.pdf?src-supplier=Digi-Key; ComponentLink2URL: https://datasheet.ciiva.com/25651/ap7361-25651049.pdf?src-supplier=Digi-Key; ComponentLink3Description: https://datasheet.ciiva.com/29568/ap7361-29568378.pdf?src-supplier=Digi-Key; ComponentLink3URL: https://datasheet.ciiva.com/29568/ap7361-29568378.pdf?src-supplier=Digi-Key; ComponentLink4Description: https://datasheet.ciiva.com/29568/ap7361-29568379.pdf?src-supplier=Digi-Key; ComponentLink4URL: https://datasheet.ciiva.com/29568/ap7361-29568379.pdf?src-supplier=Digi-Key; Contact Plating: Tin,Matte; Lead Free: Lead Free; Library Name: UDEMY_Library.IntLib; Manufacturer Part Number: AP7361-33E-13; Max Operating Temperature: 85°C; Min Operating Temperature: -40°C; Mount: Surface Mount; Number of Pins: 4; Number of Regulators: 1; Output Current: 1A; Package Quantity: 1; Packaging: Tape and Reel; Pricing 1: 1=0,54000, 10=0,43400, 25=0,39760, 100=0,29580, 250=0,26804, 500=0,22184, 1000=0,16638 (USD); Radiation Hardening: No; REACH SVHC: No SVHC; RoHS: Yes; RoHS Compliant: Yes; Series: -; Stock 1: 41247; Supplier 1: Digi-Key; Supplier Part Number 1: AP7361-33E-13DICT-ND; Weight: 0.000282oz
USB1 = Micro B USB Connector: CONN RCPT USB2.0 MICRO B SMD R/A; Manufacturer: AMPHENOL ICC (FCI); Component Kind: Standard; ComponentLink1Description: http://www.farnell.com/cad/1935767.pdf?src-supplier=Farnell; ComponentLink1URL: http://www.farnell.com/cad/1935767.pdf?src-supplier=Farnell; ComponentLink2Description: https://cdn.amphenol-icc.com/media/wysiwyg/files/documentation/datasheet/inputoutput/io_usb_micro.pdf?src-supplier=Digi-Key; ComponentLink2URL: https://cdn.amphenol-icc.com/media/wysiwyg/files/documentation/datasheet/inputoutput/io_usb_micro.pdf?src-supplier=Digi-Key; ComponentLink3Description: http://www.farnell.com/datasheets/2366786.pdf?src-supplier=Newark; ComponentLink3URL: http://www.farnell.com/datasheets/2366786.pdf?src-supplier=Newark; Library Name: UDEMY_Library.IntLib; Manufacturer Part Number: 10118192-0001LF; Pricing 1: 1400=0,249480, 2800=0,226800, 7000=0,219240, 9800=0,204120, 14000=0,201600, 35000=0,188420 (USD); RoHS: No; Stock 1: 25200; Supplier 1: Digi-Key; Supplier Part Number 1: 609-4613-2-ND
